# Altium schematic sheet Sensor_IO_Buttons_LED.SchDoc
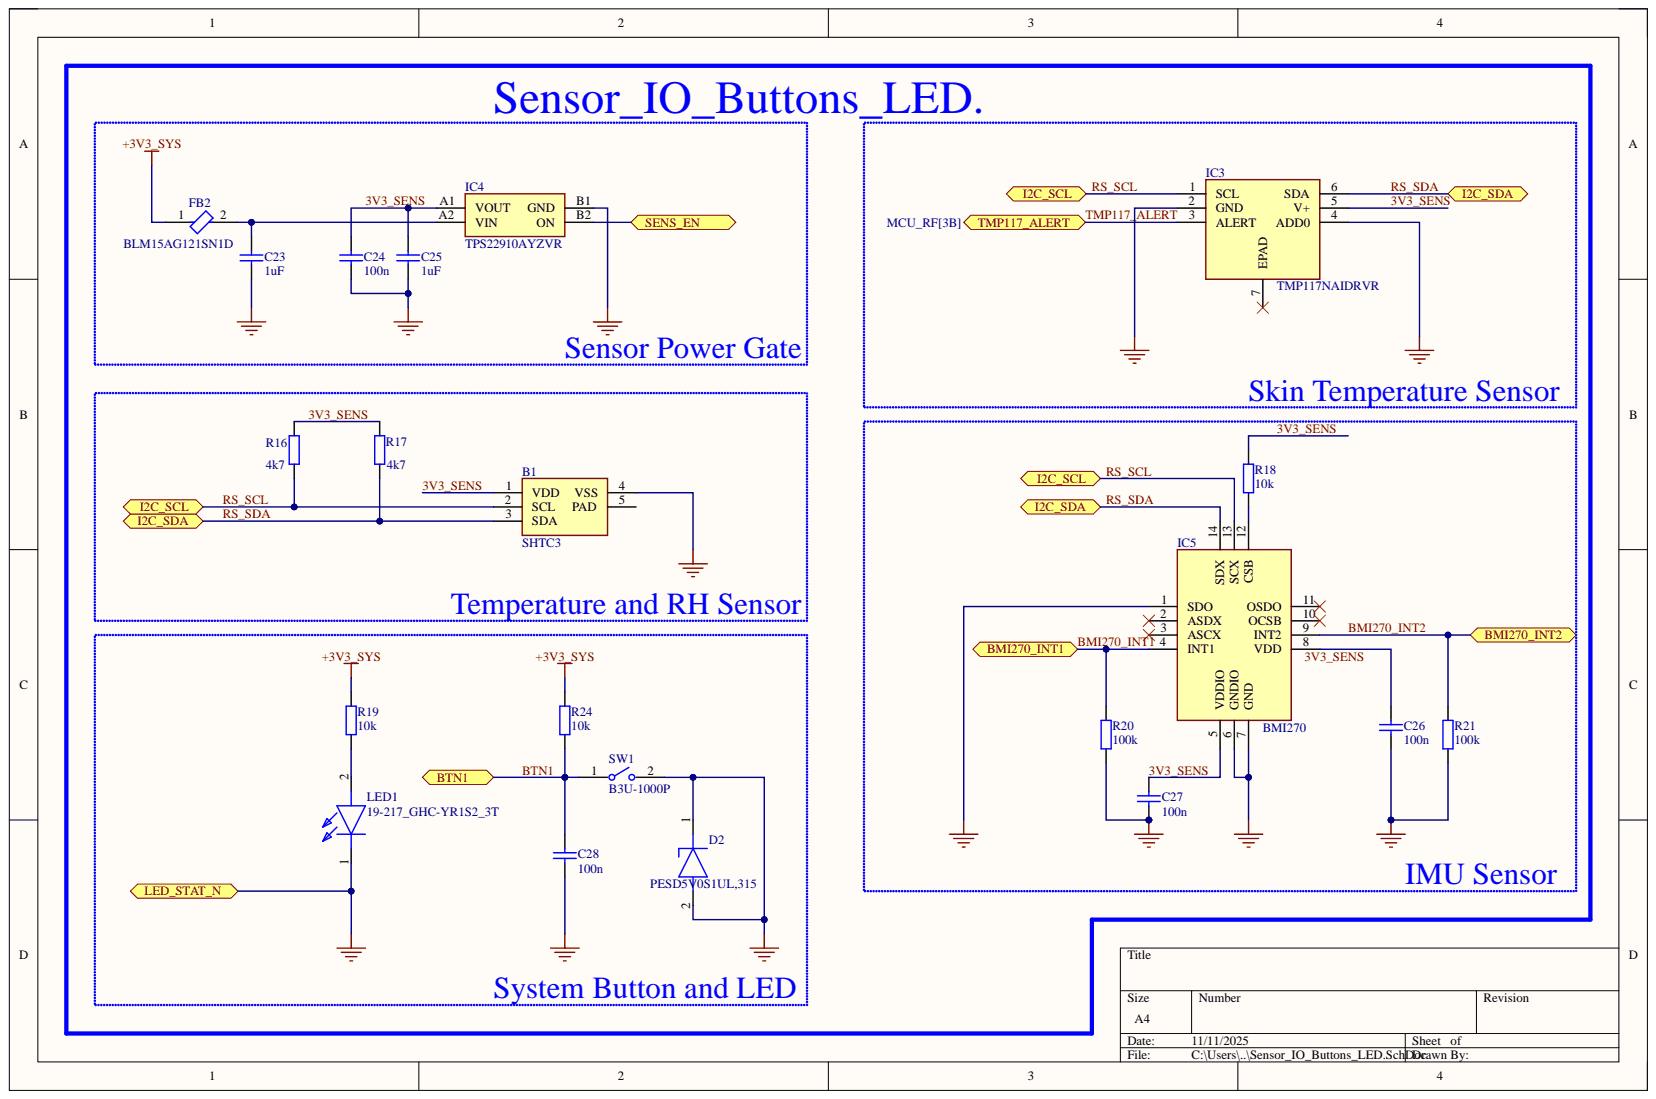
[diagram p0: Sensor_IO_Buttons_LED.SchDoc]

## Parts
B1  SHTC3  [FP-SHTC3-IPC_A]
C23  1uF  [CAP_0402]
C24  100n  [CAP_0402]
C25  1uF  [CAP_0402]
C26  100n  [CAP_0402]
C27  100n  [CAP_0402]
C28  100n  [CAP_0402]
D2  PESD5V0S1UL,315  [PESD5V0S1BL315]
FB2  BLM15AG121SN1D  [FP-BLM15-0_05-IPC_A]
IC3  TMP117NAIDRVR  [SON65P200X200X80-7N]
IC4  TPS22910AYZVR  [BGA4C50P2X2_89X89X50]
IC5  BMI270  [BMI270]
LED1  19-217_GHC-YR1S2_3T  [LEDC1608X50N]
R16  4k7  [RES_0402]
R17  4k7  [RES_0402]
R18  10k  [RES_0402]
R19  10k  [RES_0402]
R20  100k  [RES_0402]
R21  100k  [RES_0402]
R24  10k  [RES_0402]
SW1  B3U-1000P  [FP-B3U-1000P-MFG]

## Ports
BMI270_INT1, BMI270_INT2, BTN1, I2C_SCL, I2C_SDA, LED_STAT_N, SENS_EN, TMP117_ALERT

## Nets
3V3_SENS: B1.1(VDD) C24.2 C25.2 C26.2 C27.2 FB2.1 IC3.5(V+) IC4.A1(VOUT) IC5.5(VDDIO) IC5.8(VDD) R16.2 R17.2 R18.2 R19.2 R24.2
BMI270_INT1: IC5.4(INT1) R20.2 ; port BMI270_INT1
BMI270_INT2: IC5.9(INT2) R21.2 ; port BMI270_INT2
BTN1: C28.2 R24.1 SW1.1 ; port BTN1
GND: B1.4(VSS) C23.1 C24.1 C25.1 C26.1 C27.1 C28.1 D2.1 D2.2 IC3.2(GND) IC3.4(ADD0) IC4.B1(GND) IC5.1(SDO) IC5.6(GNDIO) IC5.7(GND) LED1.1 R20.1 R21.1 SW1.2 ; port LED_STAT_N
RS_SCL: B1.2(SCL) IC3.1(SCL) IC5.13(SCX) R16.1 ; port I2C_SCL
RS_SDA: B1.3(SDA) IC3.6(SDA) IC5.14(SDX) R17.1 ; port I2C_SDA
SENS_EN: IC4.B2(ON) ; port SENS_EN
TMP117_ALERT: IC3.3(ALERT) ; port TMP117_ALERT
NetC23_2: C23.2 FB2.2 IC4.A2(VIN)
NetIC5_12: IC5.12(CSB) R18.1
NetLED1_2: LED1.2 R19.1

Not connected: B1 5(PAD); IC3 7(EPAD); IC5 2(ASDX) 3(ASCX) 10(OCSB) 11(OSDO)

## Library data of the parts
B1 = SHTC3: Humidity and Temperature Sensor IC; Manufacturer_Name: Sensirion; Geometry.Height: 0.75mm; Component Kind: Standard; Datasheet Link: https://www.glynshop.com/erp/owweb/Daten/Datenblaetter/Sensirion/SHTC3.pdf; Library Name: BLE-Control.SchLib; Manufacturer_Part_Number: SHTC3; Mouser Part Number: 403-SHTC3; Mouser Price/Stock: https://www.mouser.co.uk/ProductDetail/Sensirion/SHTC3?qs=y6ZabgHbY%252Bx3LlA87fqBwg%3D%3D
C23 C25 = C_0402: Ceramic Chip Capacitor 0402; Part Number: C1005X5R1E105K; Dielectric: X7R; ProductionStatus: Placeholder; Tolerance: 10%; Voltage: 10V; Component Kind: Standard; Height: 0.35mm; Library Name: BLE-Control.SchLib; Package: 0402 (1005); PartNumber: C1005X5R1E105K
C24 C26-C28 = C_0402: Ceramic Chip Capacitor 0402; Dielectric: X7R; ProductionStatus: Placeholder; Tolerance: 10%; Voltage: 10V; Component Kind: Standard; Height: 0.35mm; Library Name: BLE-Control.SchLib; Package: 0402 (1005)
D2 = PESD5V0S1UL,315: Diode ESD (Uni-directional); Manufacturer_Name: Nexperia; Arrow Part Number: PESD5V0S1UL,315; Arrow Price/Stock: https://www.arrow.com/en/products/pesd5v0s1ul315/nexperia?utm_currency=USD&region=nac; Component Kind: Standard; Datasheet Link: https://assets.nexperia.com/documents/data-sheet/PESD5V0S1UL.pdf; Height: 0mm; Library Name: BLE-Control.SchLib; Manufacturer_Part_Number: PESD5V0S1UL,315; Mouser Part Number: 771-PESD5V0S1UL315; Mouser Price/Stock: https://www.mouser.co.uk/ProductDetail/Nexperia/PESD5V0S1UL315?qs=LOCUfHb8d9sSC%2FUB7ugsVw%3D%3D
FB2 = CMP-0686-00170-4: Chip Ferrite Bead, 0402, 120Ω @ 100MHz, 0.19Ω, 25%, 550mA; DC Current: 500mA; DC Resistance (DCR): 190mR; Impedance: 120R; Material: Steel; Number of Circuits: 1; Shielding: Unshielded; Case Code (Imperial): 0402; Case Code (Metric): 1005; Case/Package: 0402; Component Kind: Standard; Core Material: Ferrite; Current Rating: 550mA; Depth: 0.55mm; Frequency: 100MHz; Height: 0.5mm; Lead Free: Lead Free; Length: 1mm; Library Name: BLE-Control.SchLib; Max Current Rating: 550mA; Max DC Current: 500mA; Max Operating Temperature: 125°C; Military Standard: Not; Min Operating Temperature: -55°C; Number of Lines: 1; Number of Pins: 2; Package Quantity: 1; Packaging: Tape and Reel; Radiation Hardening: No; REACH SVHC: No SVHC; Termination: SMD/SMT; Test Frequency: 100MHz; Tolerance: 25%; Width: 0.5mm
IC3 = TMP117NAIDRVR: Integrated Circuit; Manufacturer_Name: Texas Instruments; Arrow Part Number: TMP117NAIDRVR; Arrow Price/Stock: https://www.arrow.com/en/products/tmp117naidrvr/texas-instruments?utm_currency=USD&region=nac; Component Kind: Standard; Datasheet Link: http://www.ti.com/lit/gpn/tmp117; Height: 0.8mm; Manufacturer_Part_Number: TMP117NAIDRVR; Mouser Part Number: 595-TMP117NAIDRVR; Mouser Price/Stock: https://www.mouser.co.uk/ProductDetail/Texas-Instruments/TMP117NAIDRVR?qs=qSfuJ%252Bfl%2Fd51FgClPJTgfg%3D%3D
IC4 = TPS22910AYZVR: Integrated Circuit; Manufacturer_Name: Texas Instruments; Arrow Part Number: TPS22910AYZVR; Arrow Price/Stock: https://www.arrow.com/en/products/tps22910ayzvr/texas-instruments?region=nac; Component Kind: Standard; Datasheet Link: http://www.ti.com/lit/gpn/tps22910a; Height: 0.5mm; Library Name: BLE-Control.SchLib; Manufacturer_Part_Number: TPS22910AYZVR; Mouser Part Number: 595-TPS22910AYZVR; Mouser Price/Stock: https://www.mouser.co.uk/ProductDetail/Texas-Instruments/TPS22910AYZVR?qs=luhu8ESwuKxMmMNtGQ7xTg%3D%3D
IC5 = BMI270: Integrated Circuit; Manufacturer_Name: BOSCH; Arrow Part Number: BMI270; Arrow Price/Stock: https://www.arrow.com/en/products/bmi270/bosch-sensortec?utm_currency=USD&region=nac; Component Kind: Standard; Datasheet Link: https://www.bosch-sensortec.com/media/boschsensortec/downloads/datasheets/bst-bmi270-ds000.pdf; Height: 0.87mm; Manufacturer_Part_Number: BMI270; Mouser Part Number: 262-BMI270; Mouser Price/Stock: https://www.mouser.co.uk/ProductDetail/Bosch-Sensortec/BMI270?qs=u16ybLDytRYIj%252BjQEee88A%3D%3D
LED1 = 19-217_GHC-YR1S2_3T: LED; Manufacturer_Name: Everlight; Manufacturer_Part_Number: 19-217/GHC-YR1S2/3T; Arrow Part Number: 19-217/GHC-YR1S2/3T; Arrow Price/Stock: https://www.arrow.com/en/products/19-217ghc-yr1s23t/everlight-electronics; Component Kind: Standard; Datasheet Link: https://www.mouser.co.uk/datasheet/2/143/19-217-GHC-YR1S2-3T-1663276.pdf; Height: 0.5mm; Library Name: BLE-Control.SchLib; Mouser Part Number: 638-19217GHCYR1S23T; Mouser Price/Stock: https://www.mouser.co.uk/ProductDetail/Everlight/19-217-GHC-YR1S2-3T?qs=8cKuZ6Ok2lZjmFTUzVFjDg%3D%3D
R16-R21 R24 = R_0402: Resistor SMD 0402; Component Kind: Standard; Library Name: BLE-Control.SchLib
SW1 = Omron B3U-1000P_Switch: SWITCH TACTILE SPST-NO 0.05A 12V; Actuator Length: 0.4mm; Actuator Type: Standard; Contact Current Rating: 50mA; Contact Resistance: 100mR; Electrical Life: 200000Cycles; Illumination Type: Non-Illuminated; Operating Force: 1.5N; Ratings: Dust Proof; Switch Function: Off-Mom; Switch Travel: 0.15mm; Throw Configuration: SPST; Component Kind: Standard; Contact Material: Silver; Current Rating: 50mA; Depth: 2.5mm; Height: 1.2mm; Insulation Resistance: 100MR; Lead Free: Lead Free; Length: 4mm; Library Name: BLE-Control.SchLib; Max Current Rating: 50mA; Max Operating Temperature: 70°C; Max Voltage Rating (DC): 12V; Mechanical Life: 200000Cycles; Min Operating Temperature: -25°C; Mount: Surface Mount; Orientation: Straight; Package Quantity: 1; Packaging: Tape and Reel; Termination: SMD/SMT; Voltage Rating (DC): 12V; Weight: 0.000776oz; Width: 3mm
